# Altium schematic sheet CAN.SchDoc(CAN)
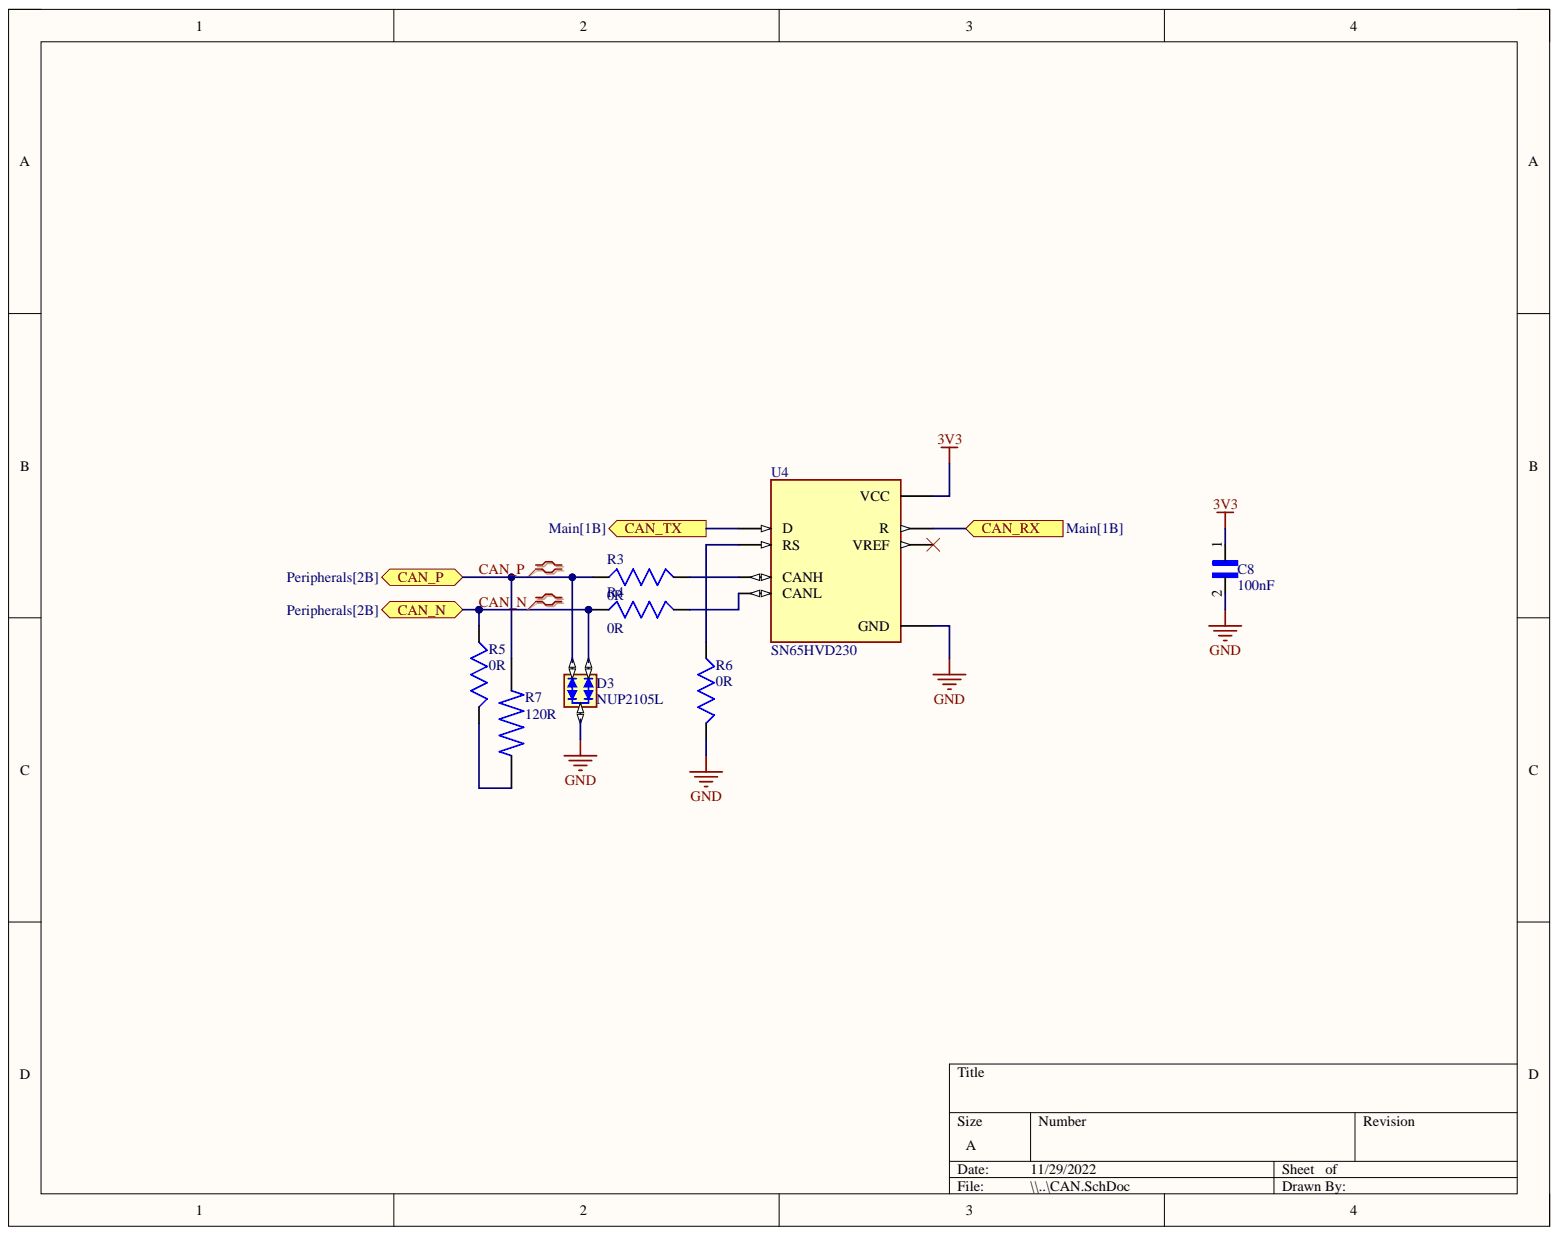
[diagram p0: CAN.SchDoc]

## Parts
C8  100nF  [CAPC2012X140N]
D3  NUP2105L  [SOT23]
R3  0R  [RESC2012X60]
R4  0R  [RESC2012X60]
R5  0R  [RESC2012X60]
R6  0R  [RESC2012X60]
R7  120R  [RESC2012X65N]
U4  SN65HVD230  [SOIC127P599X175-8N]

## Ports
CAN_N, CAN_P, CAN_RX, CAN_TX

## Nets
3V3: C8.1 U4.3(VCC)
GND: C8.2 D3.3 R6.1 U4.2(GND)
CAN_N: D3.1 R4.2 R5.2 ; port CAN_N
CAN_P: D3.2 R3.2 R7.2 ; port CAN_P
CAN_RX: U4.4(R) ; port CAN_RX
CAN_TX: U4.1(D) ; port CAN_TX
NetR3_1: R3.1 U4.7(CANH)
NetR4_1: R4.1 U4.6(CANL)
NetR5_1: R5.1 R7.1
NetR6_2: R6.2 U4.8(RS)

Not connected: U4 5(VREF)

## Library data of the parts
C8 = 08055G104ZAT1A: CAPACITOR, 0805, 100NF, 50V; Capacitor Application:General Purpose; Dielectric Characteristic:Y5V; Capacitance:0.1ÂµF; Capacitance Tolerance:-20% to +80%; Voltage Rating:50V; Capacitor Case Style:0805; Capacitor Mounting:SMD; Operating Temperature Range:-25Â°C to +85Â°C; SVHC:No SVHC (15-Dec-2010); External Depth:0.95mm; External Length / Height:2mm; External Width:1.25mm; Insulation Resistance:10000Mohm; No. of Pins:2; Operating Temperature Max:85Â°C; Operating Temperature Min:-25Â°C; Package / Case:0805; Reel Quantity:3000; Tape Width:8mm; Temperature Coefficient:+22%, -82%; Terminal Type:Surface Mount (SMD, SMT); Termination Type:SMD; Tolerance +:80%; Tolerance -:20%; Voltage Rating VDC:50V; MF: {'manufacturer': u'AVX'}; Availability: Unavailable; Component Kind: Standard; Library Name: 08055G104ZAT1A.SchLib; MP: 08055G104ZAT1A; Package: None; Price: None
D3 = NUP2105L: MF: {'manufacturer': u'Diotec Semiconductor'}; Availability: Unavailable; Component Kind: Standard; MP: NUP2105L; Package: None; Price: None
R3-R6 = RC0805JR-7W0RL: RC Series 0805 0.125 W 2 kOhms 5% Â±100 ppm/Â°C SMT Thick Film Chip Resistor; MF: {'manufacturer': u'Yageo'}; Availability: Unavailable; Component Kind: Standard; MP: RC0805JR-7W0RL; Package: None; Price: None
R7 = 1-2176327-4: Fixed Resistor CRGP 0805 120R 1%; MF: {'manufacturer': u'TE Connectivity'}; Availability: Unavailable; Component Kind: Standard; MP: 1-2176327-4; Package: None; Price: None
U4 = SN65HVD230: the sn65hvd230 sn65hvd231 and sn65hvd232 controller area network (can) transceivers are designed for use with the tex...; MF: {'manufacturer': u'Texas Instruments'}; Availability: Unavailable; Component Kind: Standard; MP: SN65HVD230; Package: None; Price: None
